# Altium schematic sheet MCU.SchDoc(MCU)
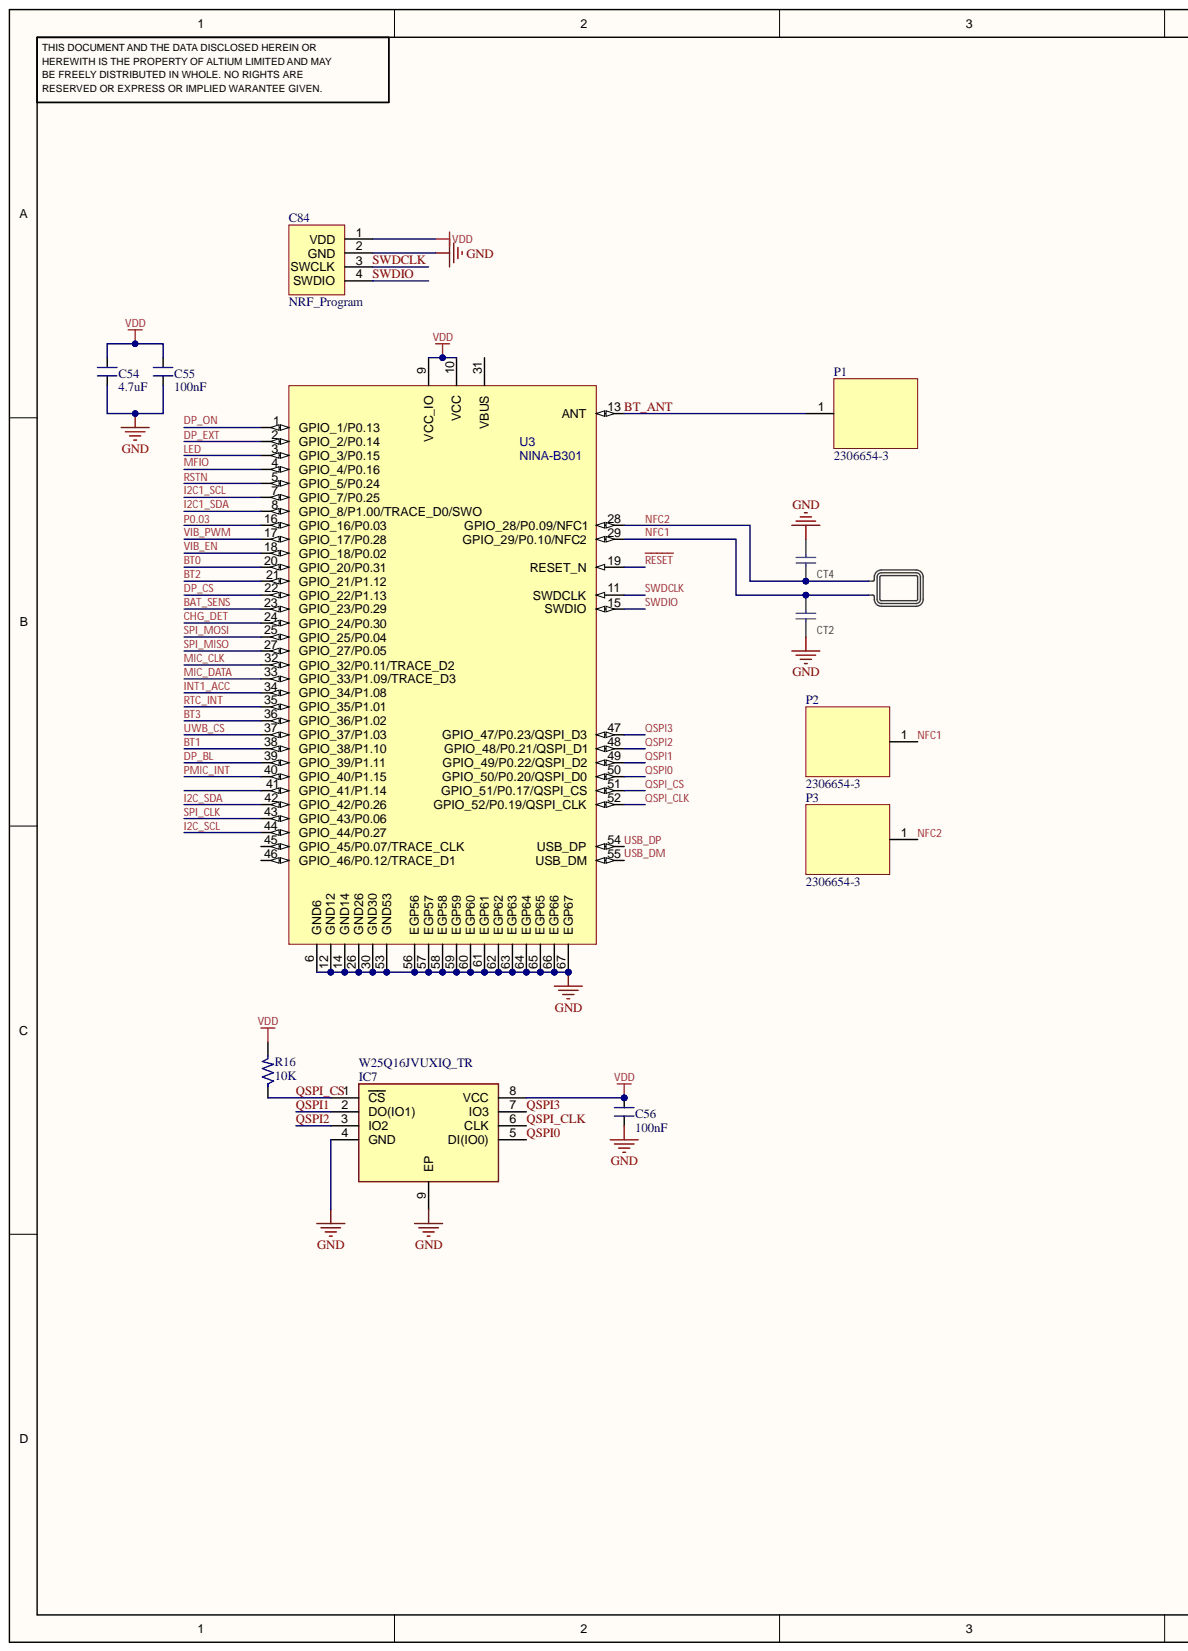
[diagram p0: MCU.SchDoc, left]
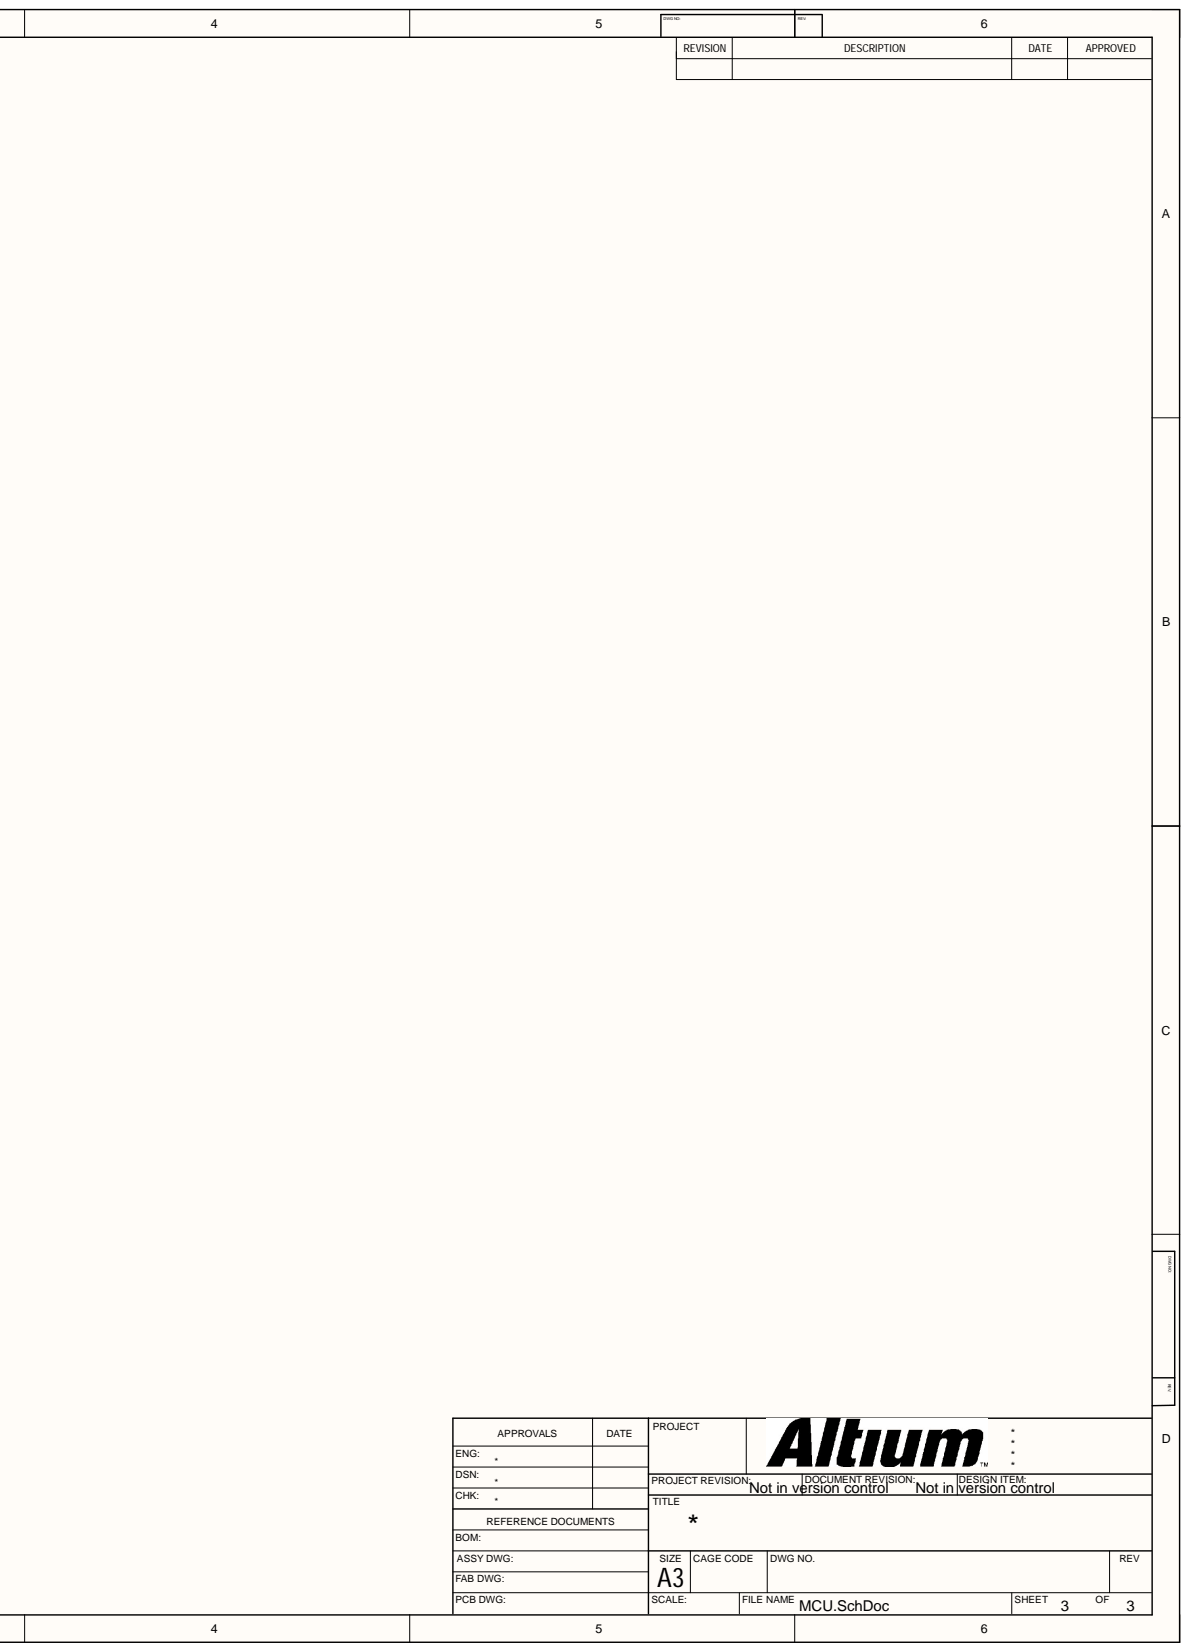
[diagram p1: MCU.SchDoc, right]

## Parts
A1  NFC Antenna  [TP_2x1mm_TH]  (value not drawn)  (no BOM)
C54  4.7uF  [CAP_0402]
C55  100nF  [CAP_0402]
C56  100nF  [CAP_0402]
C84  NRF_Program  [NRF Program]
CT2  Antenna dependent  [CAP_0402]  (value not drawn)
CT4  Antenna dependent  [CAP_0402]  (value not drawn)
IC7  W25Q16JVUXIQ_TR  [SON50P300X200X60-9N]
P1  2306654-3  [2306654-3]
P2  2306654-3  [2306654-3]
P3  2306654-3  [2306654-3]
R16  10K  [RES_0402]
U3  NINA-B301  [NINA_LGA67R_1160X1000X223_PIN]

## Nets
GND: C54.1 C55.1 C56.1 C84.2(GND) CT2.2 CT4.2 IC7.4(GND) IC7.9(EP) U3.6(GND6) U3.12(GND12) U3.14(GND14) U3.26(GND26) U3.30(GND30) U3.53(GND53) U3.56(EGP56) U3.57(EGP57) U3.58(EGP58) U3.59(EGP59) U3.60(EGP60) U3.61(EGP61) U3.62(EGP62) U3.63(EGP63) U3.64(EGP64) U3.65(EGP65) U3.66(EGP66) U3.67(EGP67)
VDD: C54.2 C55.2 C56.2 C84.1(VDD) IC7.8(VCC) R16.2 U3.9(VCC_IO) U3.10(VCC)
BAT_SENS: U3.23(GPIO_23/P0.29)
BT0: U3.20(GPIO_20/P0.31)
BT1: U3.38(GPIO_38/P1.10)
BT2: U3.21(GPIO_21/P1.12)
BT3: U3.36(GPIO_36/P1.02)
BT_ANT: P1.1 U3.13(ANT)
CHG_DET: U3.24(GPIO_24/P0.30)
DP_BL: U3.39(GPIO_39/P1.11)
DP_CS: U3.22(GPIO_22/P1.13)
DP_EXT: U3.2(GPIO_2/P0.14)
DP_ON: U3.1(GPIO_1/P0.13)
I2C1_SCL: U3.7(GPIO_7/P0.25)
I2C1_SDA: U3.8(GPIO_8/P1.00/TRACE_D0/SWO)
I2C_SCL: U3.44(GPIO_44/P0.27)
I2C_SDA: U3.42(GPIO_42/P0.26)
INT1_ACC: U3.34(GPIO_34/P1.08)
LED: U3.3(GPIO_3/P0.15)
MFIO: U3.4(GPIO_4/P0.16)
MIC_CLK: U3.32(GPIO_32/P0.11/TRACE_D2)
MIC_DATA: U3.33(GPIO_33/P1.09/TRACE_D3)
NFC1: A1.2 CT2.1 P2.1 U3.29(GPIO_29/P0.10/NFC2)
NFC2: A1.1 CT4.1 P3.1 U3.28(GPIO_28/P0.09/NFC1)
P0.03: U3.16(GPIO_16/P0.03)
PMIC_INT: U3.40(GPIO_40/P1.15)
QSPI0: IC7.5(DI(IO0)) U3.50(GPIO_50/P0.20/QSPI_D0)
QSPI1: IC7.2(DO(IO1)) U3.49(GPIO_49/P0.22/QSPI_D2)
QSPI2: IC7.3(IO2) U3.48(GPIO_48/P0.21/QSPI_D1)
QSPI3: IC7.7(IO3) U3.47(GPIO_47/P0.23/QSPI_D3)
QSPI_CLK: IC7.6(CLK) U3.52(GPIO_52/P0.19/QSPI_CLK)
QSPI_CS: IC7.1(CS) R16.1 U3.51(GPIO_51/P0.17/QSPI_CS)
~{RESET}: U3.19(RESET_N)
RSTN: U3.5(GPIO_5/P0.24)
RTC_INT: U3.35(GPIO_35/P1.01)
SPI_CLK: U3.43(GPIO_43/P0.06)
SPI_MISO: U3.27(GPIO_27/P0.05)
SPI_MOSI: U3.25(GPIO_25/P0.04)
SWDCLK: C84.3(SWCLK) U3.11(SWDCLK)
SWDIO: C84.4(SWDIO) U3.15(SWDIO)
USB_DM: U3.55(USB_DM)
USB_DP: U3.54(USB_DP)
UWB_CS: U3.37(GPIO_37/P1.03)
VIB_EN: U3.18(GPIO_18/P0.02)
VIB_PWM: U3.17(GPIO_17/P0.28)

Not connected: U3 31(VBUS) 41(GPIO_41/P1.14) 45(GPIO_45/P0.07/TRACE_CLK) 46(GPIO_46/P0.12/TRACE_D1)

## Library data of the parts
A1 = NFC_ANT_TP: Database Table Name: Parts$; Pad Count: 2; Component Class: Antennas; Description: NFC Antenna; Footprint Doc: TP_2x1mm_TH; Library Name: AltiumLib.DbLib; Value: NFC Antenna; Author: rsk
C54 = CAP_4.7uF-10V-10%-0402: 4.7µF ±10% 10V Ceramic Capacitor X5R 0402 (1005 Metric); Manufacturer: Samsung Electro-Mechanics; Manufacturer Part Number: CL05A475KP5NRNC; Case/Package: 0402; Component Kind: Standard; Supplier-1: Digikey; Library Name: EsteemPCB_SOM_Course_schlib.SchLib
C55 C56 = CAP_100nF-10V-10%-0402: 0.1µF ±10% 10V Ceramic Capacitor X7R 0402 (1005 Metric); Manufacturer: Yageo; Manufacturer Part Number: CC0402KRX7R6BB104; Case/Package: 0402; Component Kind: Standard; Supplier-1: Digikey; Library Name: EsteemPCB_SOM_Course_schlib.SchLib
CT2 CT4 = C0201-TBD: Capacitor, NP0, ±5%; Database Table Name: Parts$; Pad Count: 2; Component Class: Capacitors; Component Kind: Standard; Footprint Doc: 0201; Library Name: AltiumLib.DbLib; Value: Antenna dependent; Author: rsk
IC7 = W25Q16JVUXIQ_TR: Integrated Circuit; Manufacturer_Name: Winbond; Manufacturer_Part_Number: W25Q16JVUXIQ TR; Arrow Part Number: W25Q16JVUXIQ TR; Arrow Price/Stock: https://www.arrow.com/en/products/w25q16jvuxiqtr/winbond-electronics?utm_currency=USD&region=nac; Component Kind: Standard; Datasheet Link: https://componentsearchengine.com/Datasheets/1/W25Q16JVUXIQ TR.pdf; Height: 0.6mm; Mouser Part Number: 454-W25Q16JVUXIQTR; Mouser Price/Stock: https://www.mouser.co.uk/ProductDetail/Winbond/W25Q16JVUXIQ-TR?qs=qSfuJ%252Bfl%2Fd7SDdIHLGOd1g%3D%3D
R16 = RES_10K-0.1W-1%-0402: 10 kOhms ±1% 0.1W, 1/10W Chip Resistor 0402 (1005 Metric) Anti-Sulfur Thin Film; Manufacturer: Vishay Beyschlag/Draloric/BC Components; Manufacturer Part Number: MCS04020C1002FE000; Case/Package: 0402; Component Kind: Standard; Supplier-1: Digikey; Library Name: EsteemPCB_SOM_Course_schlib.SchLib
U3 = NINA-B301: u-blox NINA-B301 Bluetooth 5 LE, 802.15.4 module; Part Description: Stand-alone Bluetooth low energy module; Component Kind: Standard; Library Name: ubloxLib.IntLib; Manufacturer: u-blox; Value: NINA-B301
C84 = NRF_Program: Component Kind: Standard; Library Name: SamacSys.SchLib
P1-P3 = 2306654-3: Component Kind: Standard; Library Name: SamacSys.SchLib
